# Altium schematic sheet Auto_park.SchDoc
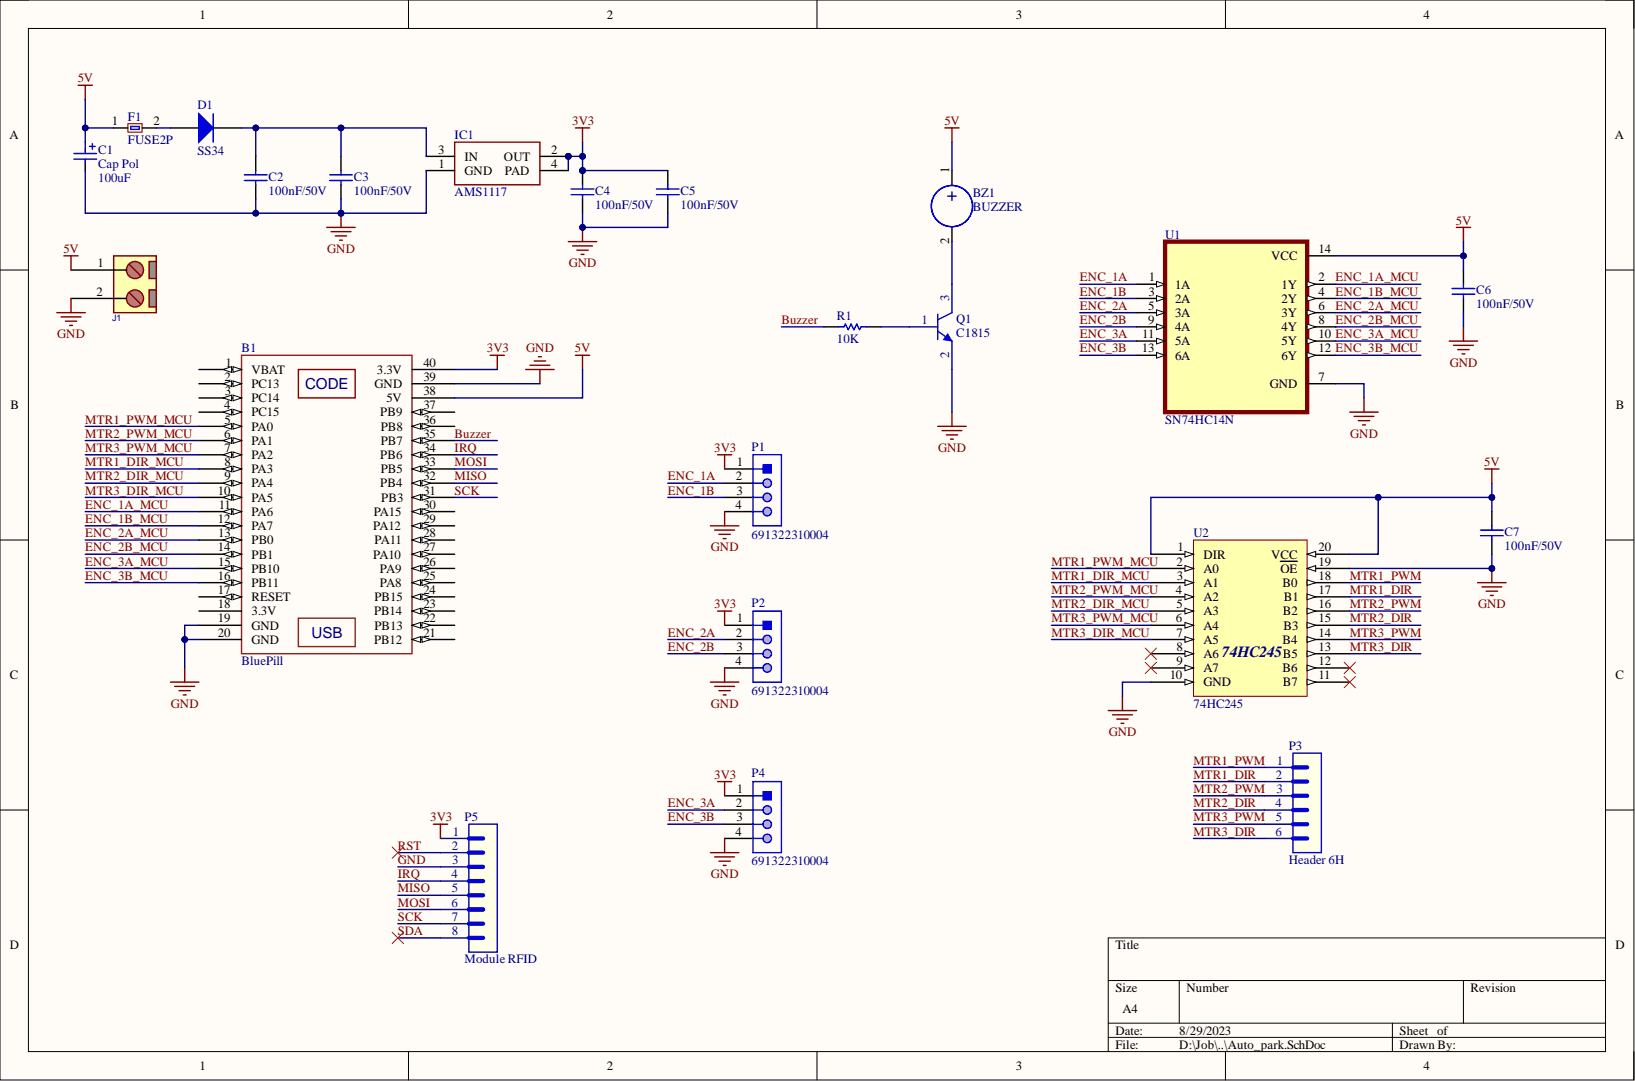
[diagram p0: Auto_park.SchDoc]

## Parts
B1  BluePill  [BlUEPILL]
BZ1  BUZZER  [BUZZER 5V]
C1  Cap Pol  value 100uF  [CP1/2]
C2  value 100nF/50V  [CAP-SM-0805]  (hidden comment: Cap-100nF-50V-0805)
C3  value 100nF/50V  [CAP-SM-0805]  (hidden comment: Cap-100nF-50V-0805)
C4  value 100nF/50V  [CAP-SM-0805]  (hidden comment: Cap-100nF-50V-0805)
C5  value 100nF/50V  [CAP-SM-0805]  (hidden comment: Cap-100nF-50V-0805)
C6  value 100nF/50V  [CAP-SM-0805]  (hidden comment: Cap-100nF-50V-0805)
C7  value 100nF/50V  [CAP-SM-0805]  (hidden comment: Cap-100nF-50V-0805)
D1  SS34  [SS34]
F1  FUSE2P  [fuse_1812]
IC1  AMS1117  [SOT223]
J1  CONN_TB2  [P_TBG_2]  (value not drawn)
P1  691322310004  [691322310004]
P2  691322310004  [691322310004]
P3  Header 6H  [JP6H]
P4  691322310004  [691322310004]
P5  Module RFID  [JP8]
Q1  C1815  [QTO-92]
R1  value 10K  [RES-SM-0805]  (hidden comment: Res-10k-0805)
U1  SN74HC14N  [DIP794W45P254L1969H508Q14]
U2  74HC245  [dip20]

## Nets
3V3: B1.40(3.3V) C4.2 C5.2 IC1.2(OUT) IC1.4(PAD) P1.1 P2.1 P4.1 P5.1
5V: B1.38(5V) BZ1.1 C1.1 C6.2 C7.2 F1.1 J1.1 U1.14(VCC) U2.1(DIR) U2.20(VCC)
Buzzer: B1.35(PB7) R1.1
ENC_1A: P1.2 U1.1(1A)
ENC_1A_MCU: B1.11(PA6) U1.2(1Y)
ENC_1B: P1.3 U1.3(2A)
ENC_1B_MCU: B1.12(PA7) U1.4(2Y)
ENC_2A: P2.2 U1.5(3A)
ENC_2A_MCU: B1.13(PB0) U1.6(3Y)
ENC_2B: P2.3 U1.9(4A)
ENC_2B_MCU: B1.14(PB1) U1.8(4Y)
ENC_3A: P4.2 U1.11(5A)
ENC_3A_MCU: B1.15(PB10) U1.10(5Y)
ENC_3B: P4.3 U1.13(6A)
ENC_3B_MCU: B1.16(PB11) U1.12(6Y)
GND: B1.19(GND) B1.20(GND) B1.39(GND) C1.2 C2.1 C3.1 C4.1 C5.1 C6.1 C7.1 IC1.1(GND) J1.2 P1.4 P2.4 P4.4 P5.3 Q1.2 U1.7(GND) U2.10(GND) U2.19(OE)
IRQ: B1.34(PB6) P5.4
MISO: B1.32(PB4) P5.5
MOSI: B1.33(PB5) P5.6
MTR1_DIR: P3.2 U2.17(B1)
MTR1_DIR_MCU: B1.8(PA3) U2.3(A1)
MTR1_PWM: P3.1 U2.18(B0)
MTR1_PWM_MCU: B1.5(PA0) U2.2(A0)
MTR2_DIR: P3.4 U2.15(B3)
MTR2_DIR_MCU: B1.9(PA4) U2.5(A3)
MTR2_PWM: P3.3 U2.16(B2)
MTR2_PWM_MCU: B1.6(PA1) U2.4(A2)
MTR3_DIR: P3.6 U2.13(B5)
MTR3_DIR_MCU: B1.10(PA5) U2.7(A5)
MTR3_PWM: P3.5 U2.14(B4)
MTR3_PWM_MCU: B1.7(PA2) U2.6(A4)
RST: P5.2
SCK: B1.31(PB3) P5.7
SDA: P5.8
NetBZ1_2: BZ1.2 Q1.3
NetC2_2: C2.2 C3.2 D1.1 IC1.3(IN)
NetD1_2: D1.2 F1.2
NetQ1_1: Q1.1 R1.2

Not connected: B1 1(VBAT) 2(PC13) 3(PC14) 4(PC15) 17(RESET) 18(3.3V) 21(PB12) 22(PB13) 23(PB14) 24(PB15) 25(PA8) 26(PA9) 27(PA10) 28(PA11) 29(PA12) 30(PA15) 36(PB8) 37(PB9); U2 8(A6) 9(A7) 11(B7) 12(B6)

## Library data of the parts
BZ1 = BUZZER 5V: Component Kind: Standard; Library Name: MCTA_V2_070322_ESP32_RELAY.IntLib
C1 = Cap-TH-Pol: Polarized Capacitor (Radial); Component Kind: Standard; Library Name: integrated_lib.IntLib
C2-C7 = Cap-100nF-50V-0805: CAP CER 0.1UF 50V Y5V 0805; Manufacturer: Samsung Electro-Mechanics; Manufacturer Part Number: CL21F104ZBCNNNC; Component Kind: Standard; Library Name: integrated_lib.IntLib; Local Supplier: thegioiic; Local Supplier Link: https://thegioiic.com/products/tu-100nf-0-1uf-50v-0805; Supplier 1: Digikey; Supplier 1 Link: https://www.digikey.com/product-detail/en/samsung-electro-mechanics/CL21F104ZBCNNNC/1276-1007-1-ND/3889093; Supplier 1 Part Number: 1276-1007-1-ND
D1 = Diode-SS34: DIODE SCHOTTKY 40V 3A SMC; Manufacturer: ON Semiconductor; Component Kind: Standard; Library Name: integrated_lib.IntLib; Local Supplier: thegioiic; Local Supplier Link: https://thegioiic.com/products/ss34-sma; Manufacturer Part Number: SS34; Supplier 1: Digikey; Supplier 1 Link: https://www.digikey.com/product-detail/en/on-semiconductor/SS34/SS34FSCT-ND/2094459; Supplier 1 Part Number: SS34FSCT-ND
F1 = FUSE: Component Kind: Standard; Library Name: MCTA_V2_070322_ESP32_RELAY.IntLib
IC1 = AMS1117: Available in 1.8V, 2.5V, 2.85V, 3.3V, 5V, and  Adjustable Versions; Value: LM317; Component Kind: Standard; Library Name: integrated_lib.IntLib
J1 = TB2 GREEN: CONN, TERMINAL BLOCK, 5.08mm pitch; Component Kind: Standard; VALUE: CONN_TB2; Library Name: MCTA_V2_070322_ESP32_RELAY.IntLib
P1 P2 P4 = 691322310004: WR-TBL Serie 322 Horizontal PCB Header, Pluggable, pitch 3.81mm, 4p; Compliance: RoHS; Modularity: No; Pin Number: 4; Rated Current [UL]: 10A; Working Voltage [UL]: 300VAC; Application: Pluggable; Component Kind: Standard; ComponentLink1Description: Manufacturer; ComponentLink1URL: http://www.we-online.de; ComponentLink2Description: Datasheet; ComponentLink2URL: https://katalog.we-online.de/em/datasheet/691322310004.pdf; Library Name: 691322310002..IntLib; Mounting Technology: THT; Operating Temperature: -40 to +105degC; Packaging: Box; Part Number: 691322310004; PCB/Cable/Panel: PCB; Pitch: 3.81mm; Published Date: October 2019; Type: Horizontal; Publisher: Wurth Elektronik
P3 = Header 6H: Header, 6-Pin, Right Angle; Component Kind: Standard; LatestRevisionDate: 17-Jul-2002; LatestRevisionNote: Re-released for DXP Platform.; Library Name: integrated_lib.IntLib; Publisher: Altium Limited
P5 = Header 8: Header, 8-Pin; Component Kind: Standard; LatestRevisionDate: 17-Jul-2002; LatestRevisionNote: Re-released for DXP Platform.; Library Name: integrated_lib.IntLib; Publisher: Altium Limited
R1 = Res-10k-0805: RES 10K OHM 1% 1/4W 0805; Manufacturer: Stackpole Electronics Inc; Manufacturer Part Number: RNCP0805FTD10K0; Component Kind: Standard; Library Name: integrated_lib.IntLib; Local Supplier: thegioiic; Local Supplier Link: https://thegioiic.com/products/dien-tro-10k-ohm-0805-5; Supplier 1: Digikey; Supplier 1 Link: https://www.digikey.com/product-detail/en/stackpole-electronics-inc/RNCP0805FTD10K0/RNCP0805FTD10K0CT-ND/2240601; Supplier 1 Part Number: RNCP0805FTD10K0CT-ND
U1 = SN74HC14N: 6-ch, 2-V to 6-V inverters with Schmitt-Trigger inputs; MF: Texas Instruments; Availability: In Stock; Check_prices: https://www.snapeda.com/parts/SN74HC14N/Texas+Instruments/view-part/?ref=eda; Component Kind: Standard; Library Name: SN74HC14N.IntLib; MP: SN74HC14N; Package: PDIP-14 Texas Instruments; Price: None; Purchase-URL: https://pricing.snapeda.com/search/part/SN74HC14N/?ref=eda; SnapEDA_Link: https://www.snapeda.com/parts/SN74HC14N/Texas+Instruments/view-part/?ref=snap
U2 = 74HC245: Dán; Don_Vi: Con; Ma_Hang: MH003571; Ten_Linh_Kien: 74HC245DW SSOP20 5.2MM; Chung_Loai: Dán; Component Kind: Standard; Library Name: THU VIEN SCH.SchLib
B1 = BluePill: Component Kind: Standard; Library Name: integrated_lib.IntLib
Q1 = C1815: Component Kind: Standard; Library Name: integrated_lib.IntLib
